# Altium schematic sheet Connector.SchDoc
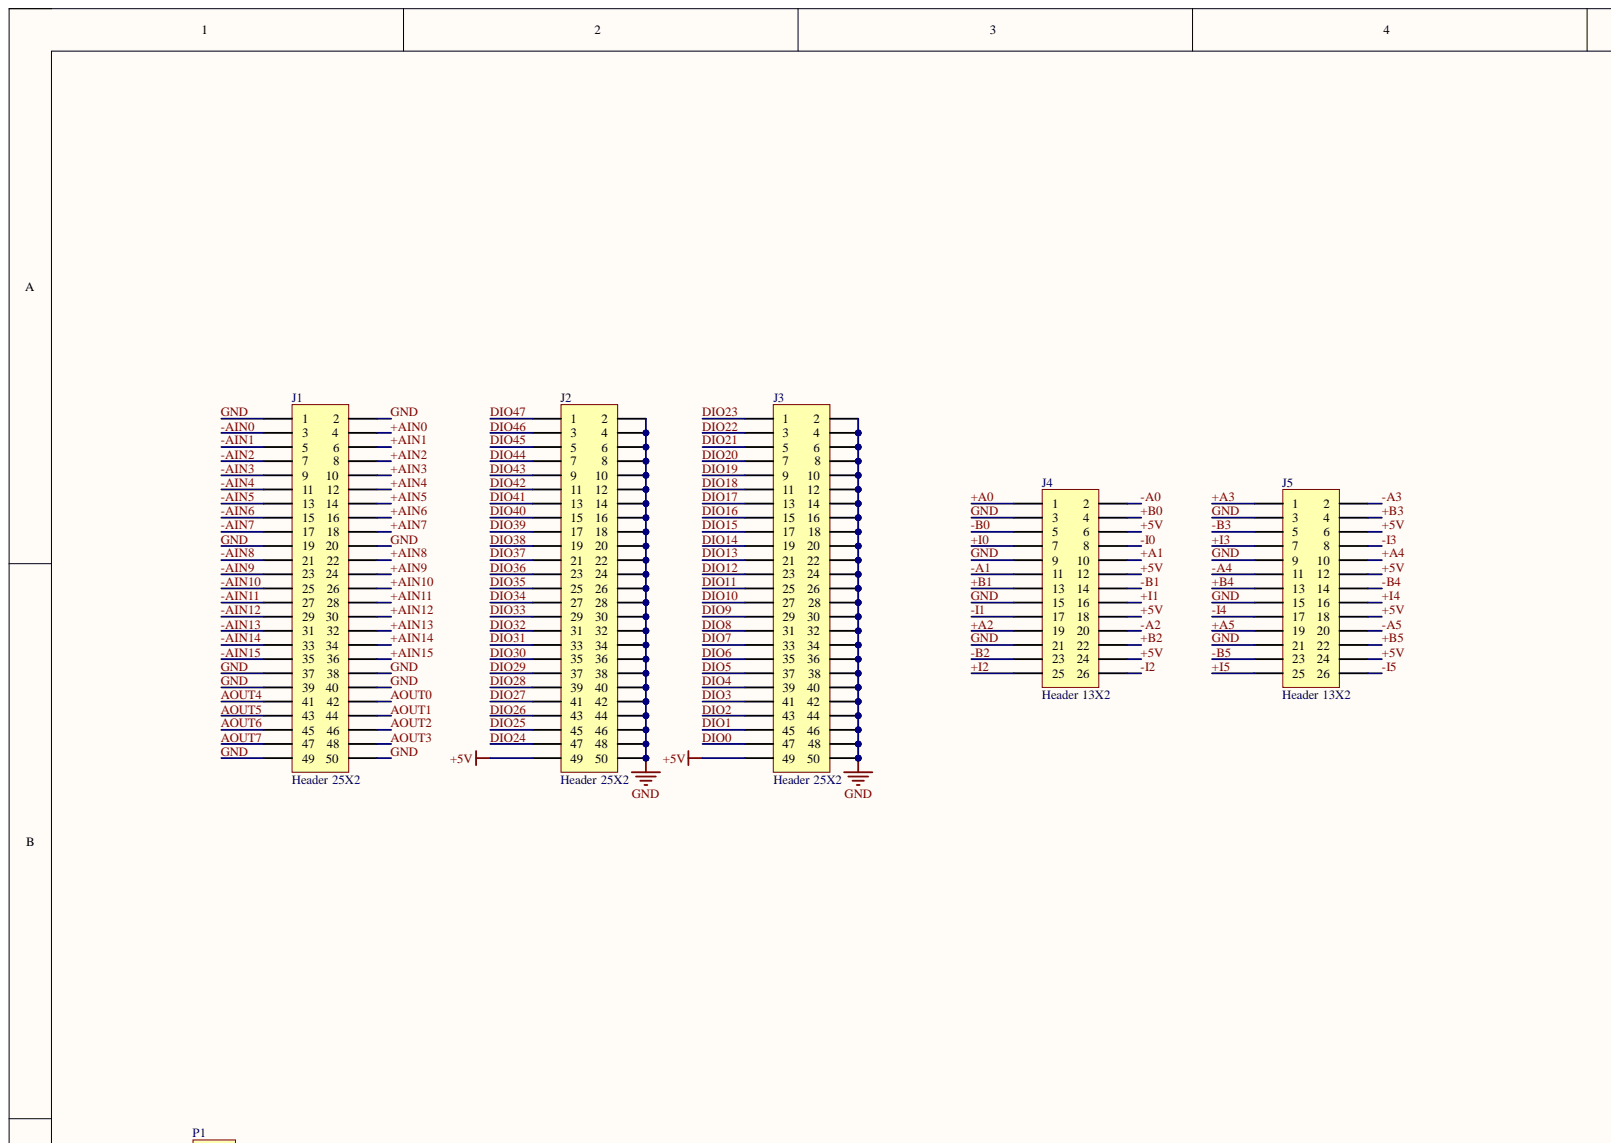
[diagram p0: Connector.SchDoc, top left]
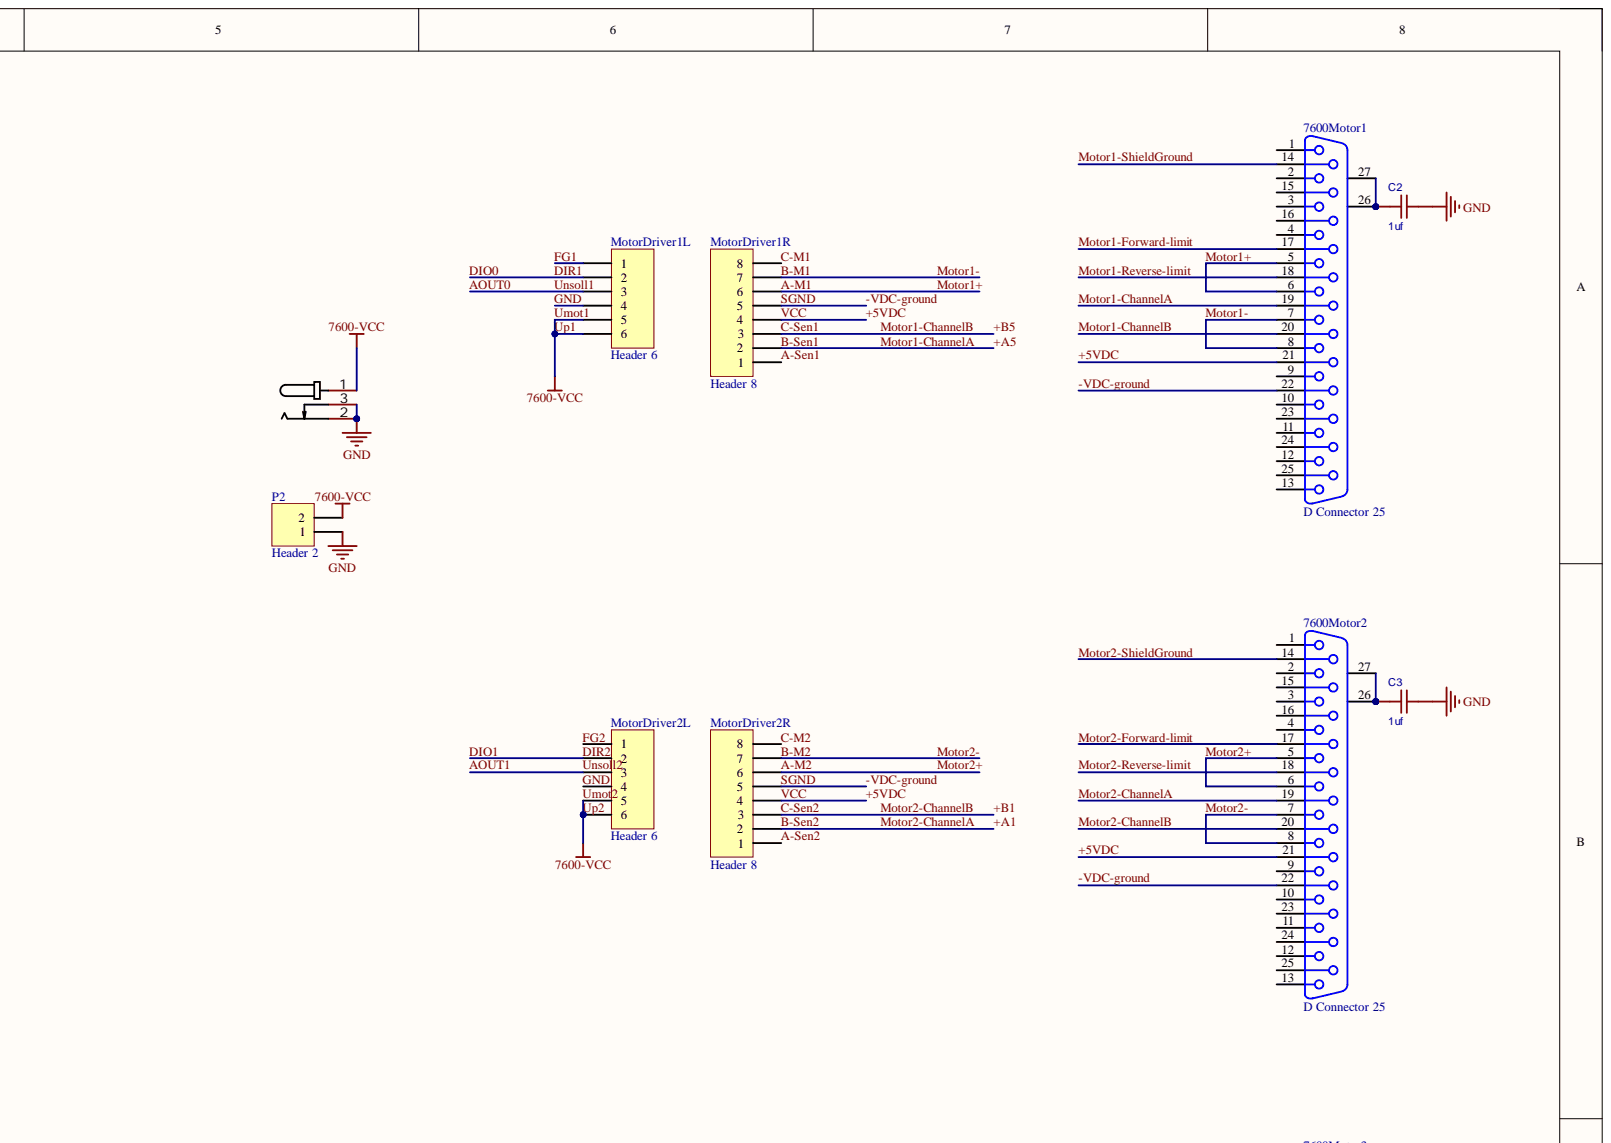
[diagram p1: Connector.SchDoc, top right]
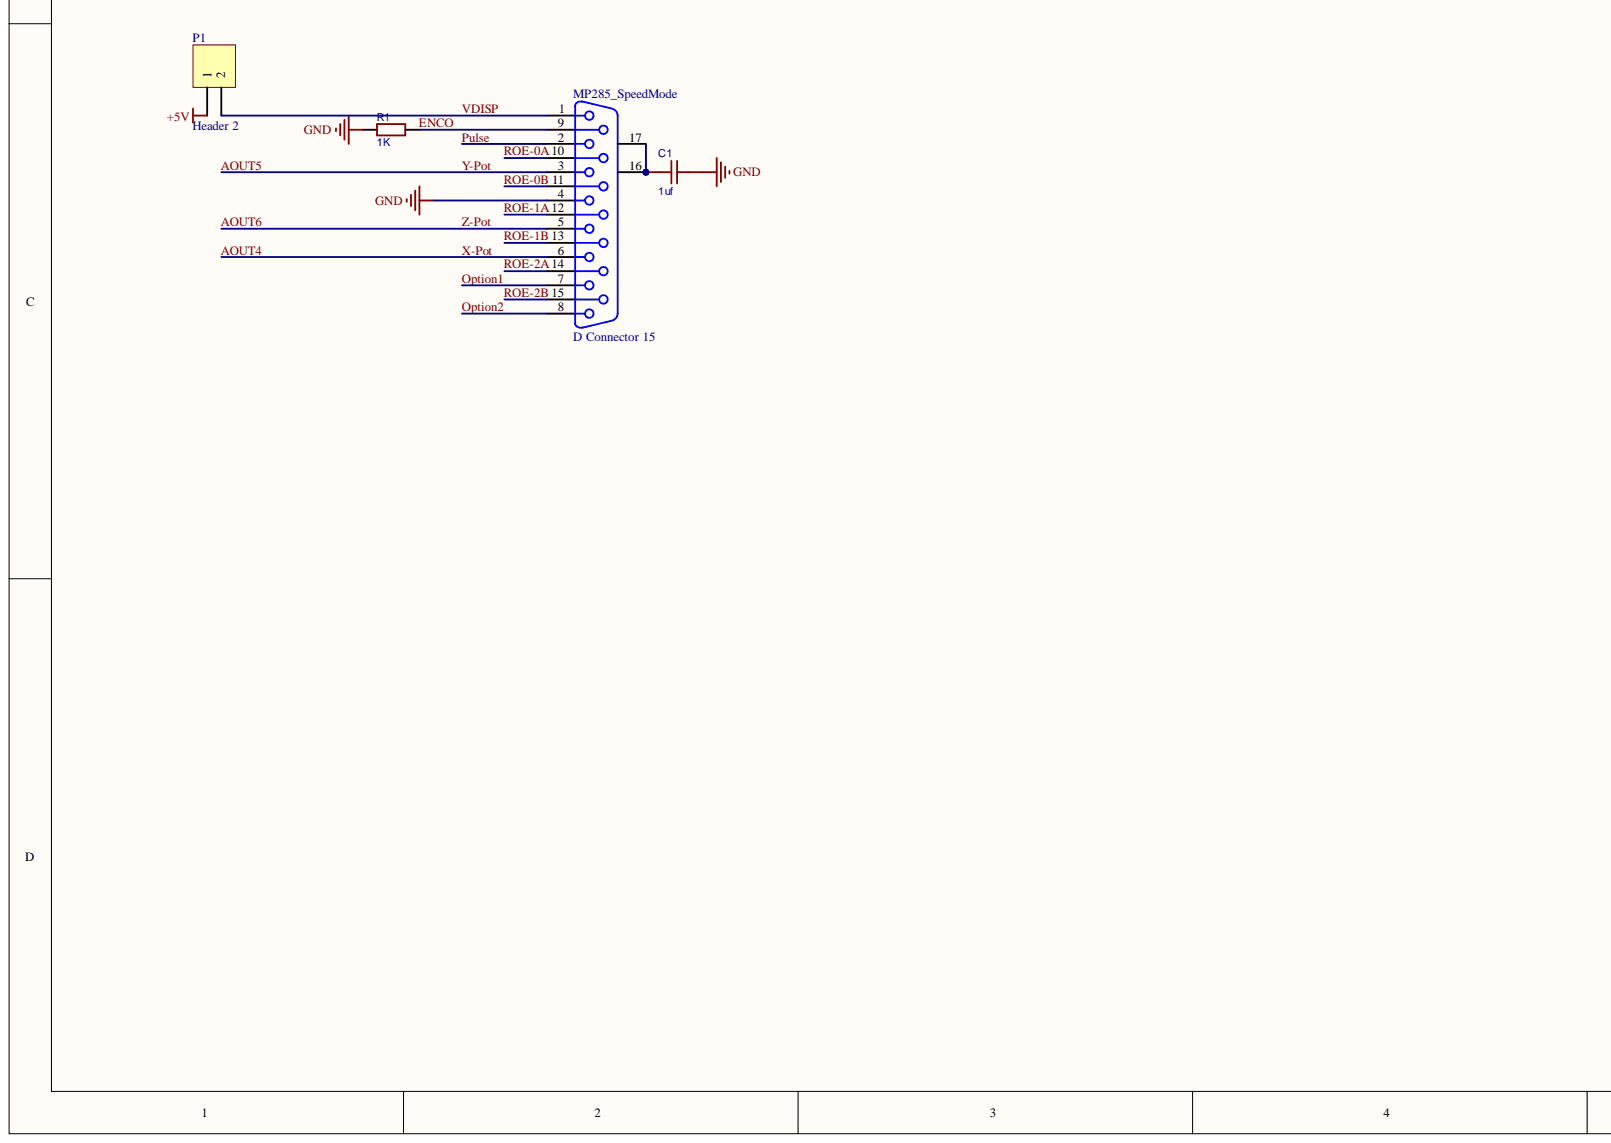
[diagram p2: Connector.SchDoc, bottom left]
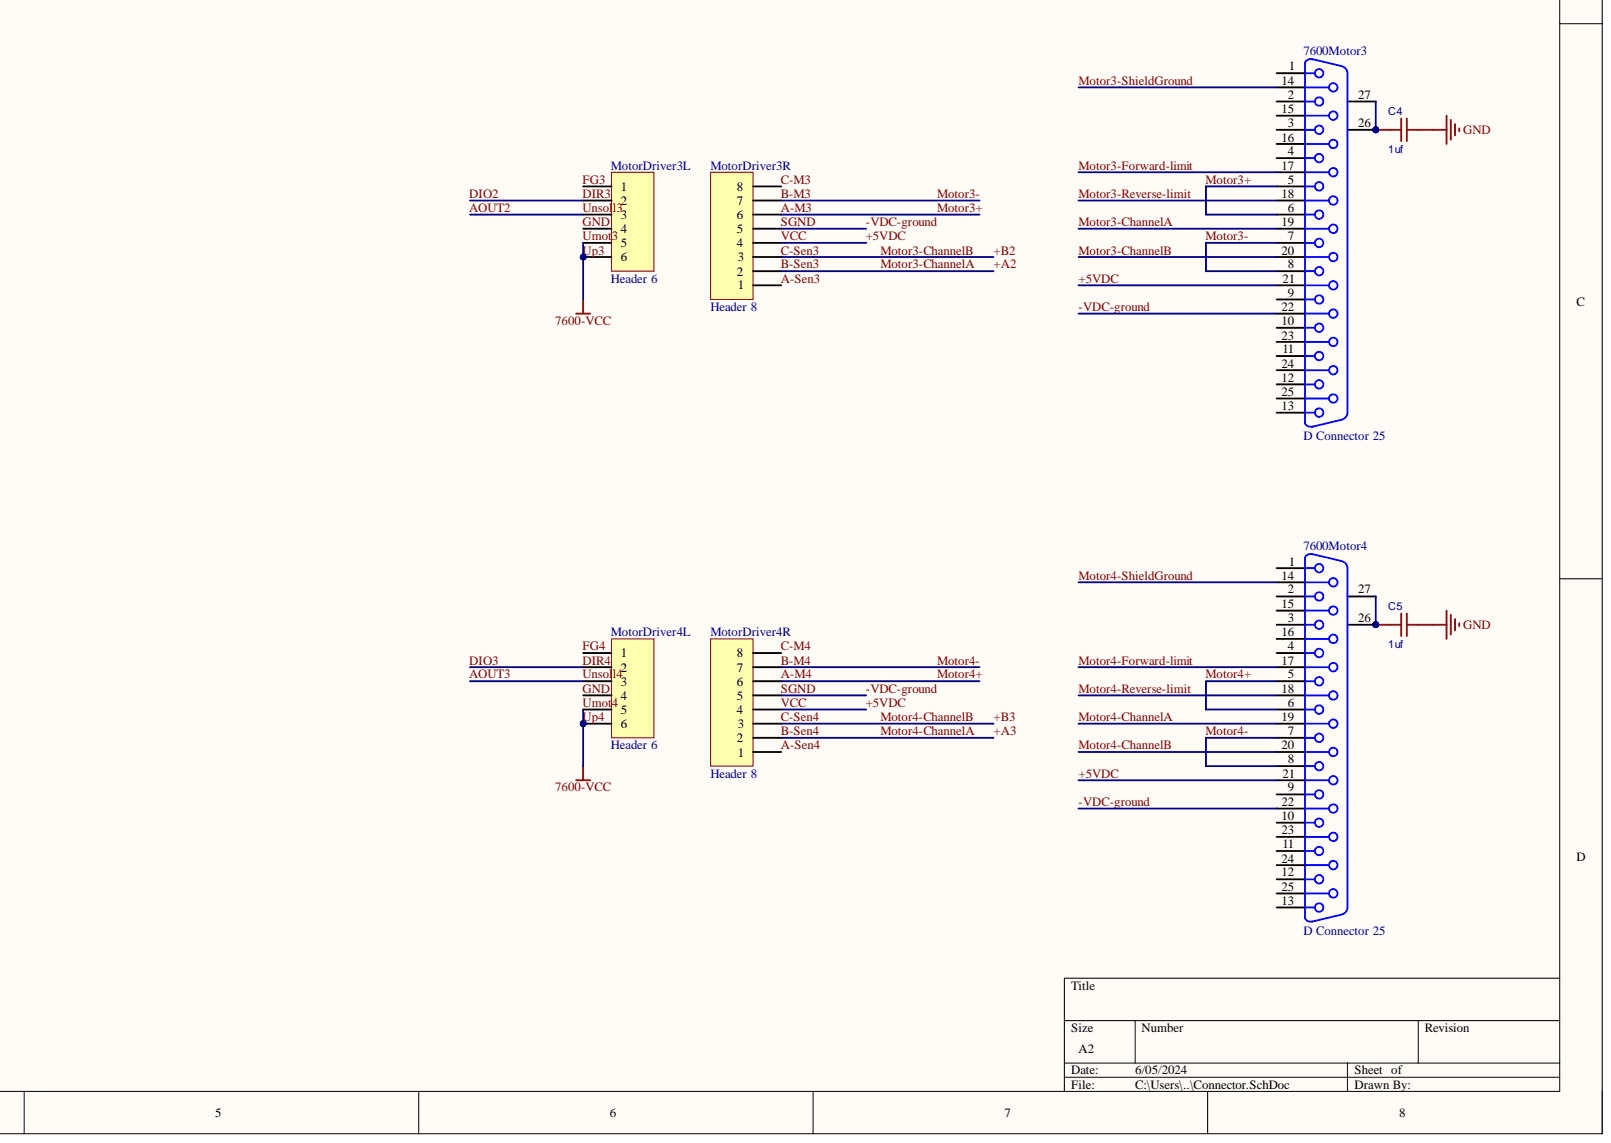
[diagram p3: Connector.SchDoc, bottom right]

## Parts
7600Motor1  D Connector 25  [DSUB1.385-2H25A]
7600Motor2  D Connector 25  [DSUB1.385-2H25A]
7600Motor3  D Connector 25  [DSUB1.385-2H25A]
7600Motor4  D Connector 25  [DSUB1.385-2H25A]
C1  1uf  [0603C]
C2  1uf  [0603C]
C3  1uf  [0603C]
C4  1uf  [0603C]
C5  1uf  [0603C]
DC1  DC-005-A200  [DC-IN-TH_DC-005-A200]  (value not drawn)
J1  Header 25X2  [HDR2X25]
J2  Header 25X2  [HDR2X25]
J3  Header 25X2  [HDR2X25]
J4  Header 13X2  [HDR2X13]
J5  Header 13X2  [HDR2X13]
MotorDriver1L  Header 6  [HDR1X6]
MotorDriver1R  Header 8  [HDR1X8]
MotorDriver2L  Header 6  [HDR1X6]
MotorDriver2R  Header 8  [HDR1X8]
MotorDriver3L  Header 6  [HDR1X6]
MotorDriver3R  Header 8  [HDR1X8]
MotorDriver4L  Header 6  [HDR1X6]
MotorDriver4R  Header 8  [HDR1X8]
MP285_SpeedMode  D Connector 15  [DSUB1.385-2H15]
P1  Header 2  [HDR1X2]
P2  Header 2  [HDR1X2]
R1  1K  [0603R]

## Nets
+5V: J2.49 J3.49 J4.6 J4.12 J4.18 J4.24 J5.6 J5.12 J5.18 J5.24 P1.1
+5VDC: 7600Motor1.21 7600Motor2.21 7600Motor3.21 7600Motor4.21 MotorDriver1R.4 MotorDriver2R.4 MotorDriver3R.4 MotorDriver4R.4
+A0: J4.1
+A1: 7600Motor2.19 J4.10 MotorDriver2R.2
+A2: 7600Motor3.19 J4.19 MotorDriver3R.2
+A3: 7600Motor4.19 J5.1 MotorDriver4R.2
+A4: J5.10
+A5: 7600Motor1.19 J5.19 MotorDriver1R.2
+AIN0: J1.4
+AIN1: J1.6
+AIN2: J1.8
+AIN3: J1.10
+AIN4: J1.12
+AIN5: J1.14
+AIN6: J1.16
+AIN7: J1.18
+AIN8: J1.22
+AIN9: J1.24
+AIN10: J1.26
+AIN11: J1.28
+AIN12: J1.30
+AIN13: J1.32
+AIN14: J1.34
+AIN15: J1.36
+B0: J4.4
+B1: 7600Motor2.20 J4.13 MotorDriver2R.3
+B2: 7600Motor3.20 J4.22 MotorDriver3R.3
+B3: 7600Motor4.20 J5.4 MotorDriver4R.3
+B4: J5.13
+B5: 7600Motor1.20 J5.22 MotorDriver1R.3
+I0: J4.7
+I1: J4.16
+I2: J4.25
+I3: J5.7
+I4: J5.16
+I5: J5.25
-A0: J4.2
-A1: J4.11
-A2: J4.20
-A3: J5.2
-A4: J5.11
-A5: J5.20
-AIN0: J1.3
-AIN1: J1.5
-AIN2: J1.7
-AIN3: J1.9
-AIN4: J1.11
-AIN5: J1.13
-AIN6: J1.15
-AIN7: J1.17
-AIN8: J1.21
-AIN9: J1.23
-AIN10: J1.25
-AIN11: J1.27
-AIN12: J1.29
-AIN13: J1.31
-AIN14: J1.33
-AIN15: J1.35
-B0: J4.5
-B1: J4.14
-B2: J4.23
-B3: J5.5
-B4: J5.14
-B5: J5.23
-I0: J4.8
-I1: J4.17
-I2: J4.26
-I3: J5.8
-I4: J5.17
-I5: J5.26
-VDC-ground: 7600Motor1.22 7600Motor2.22 7600Motor3.22 7600Motor4.22 MotorDriver1R.5 MotorDriver2R.5 MotorDriver3R.5 MotorDriver4R.5
A-M1: 7600Motor1.5 7600Motor1.6 MotorDriver1R.6
A-M2: 7600Motor2.5 7600Motor2.6 MotorDriver2R.6
A-M3: 7600Motor3.5 7600Motor3.6 MotorDriver3R.6
A-M4: 7600Motor4.5 7600Motor4.6 MotorDriver4R.6
A-Sen1: MotorDriver1R.1
A-Sen2: MotorDriver2R.1
A-Sen3: MotorDriver3R.1
A-Sen4: MotorDriver4R.1
AOUT0: J1.42 MotorDriver1L.3
AOUT1: J1.44 MotorDriver2L.3
AOUT2: J1.46 MotorDriver3L.3
AOUT3: J1.48 MotorDriver4L.3
AOUT4: J1.41 MP285_SpeedMode.6
AOUT5: J1.43 MP285_SpeedMode.3
AOUT6: J1.45 MP285_SpeedMode.5
AOUT7: J1.47
B-M1: 7600Motor1.7 7600Motor1.8 MotorDriver1R.7
B-M2: 7600Motor2.7 7600Motor2.8 MotorDriver2R.7
B-M3: 7600Motor3.7 7600Motor3.8 MotorDriver3R.7
B-M4: 7600Motor4.7 7600Motor4.8 MotorDriver4R.7
C-M1: MotorDriver1R.8
C-M2: MotorDriver2R.8
C-M3: MotorDriver3R.8
C-M4: MotorDriver4R.8
DIO0: J3.47 MotorDriver1L.2
DIO1: J3.45 MotorDriver2L.2
DIO2: J3.43 MotorDriver3L.2
DIO3: J3.41 MotorDriver4L.2
DIO4: J3.39
DIO5: J3.37
DIO6: J3.35
DIO7: J3.33
DIO8: J3.31
DIO9: J3.29
DIO10: J3.27
DIO11: J3.25
DIO12: J3.23
DIO13: J3.21
DIO14: J3.19
DIO15: J3.17
DIO16: J3.15
DIO17: J3.13
DIO18: J3.11
DIO19: J3.9
DIO20: J3.7
DIO21: J3.5
DIO22: J3.3
DIO23: J3.1
DIO24: J2.47
DIO25: J2.45
DIO26: J2.43
DIO27: J2.41
DIO28: J2.39
DIO29: J2.37
DIO30: J2.35
DIO31: J2.33
DIO32: J2.31
DIO33: J2.29
DIO34: J2.27
DIO35: J2.25
DIO36: J2.23
DIO37: J2.21
DIO38: J2.19
DIO39: J2.17
DIO40: J2.15
DIO41: J2.13
DIO42: J2.11
DIO43: J2.9
DIO44: J2.7
DIO45: J2.5
DIO46: J2.3
DIO47: J2.1
ENCO: MP285_SpeedMode.9 R1.2
FG1: MotorDriver1L.1
FG2: MotorDriver2L.1
FG3: MotorDriver3L.1
FG4: MotorDriver4L.1
GND: C1.2 C2.2 C3.2 C4.2 C5.2 DC1.2 DC1.3 J1.1 J1.2 J1.19 J1.20 J1.37 J1.38 J1.39 J1.40 J1.49 J1.50 J2.2 J2.4 J2.6 J2.8 J2.10 J2.12 J2.14 J2.16 J2.18 J2.20 J2.22 J2.24 J2.26 J2.28 J2.30 J2.32 J2.34 J2.36 J2.38 J2.40 J2.42 J2.44 J2.46 J2.48 J2.50 J3.2 J3.4 J3.6 J3.8 J3.10 J3.12 J3.14 J3.16 J3.18 J3.20 J3.22 J3.24 J3.26 J3.28 J3.30 J3.32 J3.34 J3.36 J3.38 J3.40 J3.42 J3.44 J3.46 J3.48 J3.50 J4.3 J4.9 J4.15 J4.21 J5.3 J5.9 J5.15 J5.21 MotorDriver1L.4 MotorDriver2L.4 MotorDriver3L.4 MotorDriver4L.4 MP285_SpeedMode.4 P2.1 R1.1
Motor1-Forward-limit: 7600Motor1.17
Motor1-Reverse-limit: 7600Motor1.18
Motor1-ShieldGround: 7600Motor1.14
Motor2-Forward-limit: 7600Motor2.17
Motor2-Reverse-limit: 7600Motor2.18
Motor2-ShieldGround: 7600Motor2.14
Motor3-Forward-limit: 7600Motor3.17
Motor3-Reverse-limit: 7600Motor3.18
Motor3-ShieldGround: 7600Motor3.14
Motor4-Forward-limit: 7600Motor4.17
Motor4-Reverse-limit: 7600Motor4.18
Motor4-ShieldGround: 7600Motor4.14
Option1: MP285_SpeedMode.7
Option2: MP285_SpeedMode.8
Pulse: MP285_SpeedMode.2
ROE-0A: MP285_SpeedMode.10
ROE-0B: MP285_SpeedMode.11
ROE-1A: MP285_SpeedMode.12
ROE-1B: MP285_SpeedMode.13
ROE-2A: MP285_SpeedMode.14
ROE-2B: MP285_SpeedMode.15
Umot1: DC1.1 MotorDriver1L.5 MotorDriver1L.6 MotorDriver2L.5 MotorDriver2L.6 MotorDriver3L.5 MotorDriver3L.6 MotorDriver4L.5 MotorDriver4L.6 P2.2
VDISP: MP285_SpeedMode.1 P1.2
Net7600Motor1_26: 7600Motor1.26 7600Motor1.27 C2.1
Net7600Motor2_26: 7600Motor2.26 7600Motor2.27 C3.1
Net7600Motor3_26: 7600Motor3.26 7600Motor3.27 C4.1
Net7600Motor4_26: 7600Motor4.26 7600Motor4.27 C5.1
NetC1_1: C1.1 MP285_SpeedMode.16 MP285_SpeedMode.17

Not connected: 7600Motor1 1 2 3 4 9 10 11 12 13 15 16 23 24 25; 7600Motor2 1 2 3 4 9 10 11 12 13 15 16 23 24 25; 7600Motor3 1 2 3 4 9 10 11 12 13 15 16 23 24 25; 7600Motor4 1 2 3 4 9 10 11 12 13 15 16 23 24 25

## Library data of the parts
7600Motor1-7600Motor4 = D Connector 25: Receptacle Assembly, 25 Position, Right Angle; PackageDocument: U; Positions: 25; Component Kind: Standard; DatasheetDocument: 19-Mar-1999; Gender: Receptacle; LatestRevisionDate: 17-Jul-2002; LatestRevisionNote: Re-released for DXP Platform.; Library Name: Miscellaneous Connectors.IntLib; Orientation: Right Angle; PackageReference: 787202; Published: 15-Jun-2001; Signal Integrity: Connector; Publisher: Altium Limited
C1-C5 = 1uf: BOM_Manufacturer: Murata Electronics; BOM_Manufacturer Part: GRM0335C1H150FA01D; Contributor: LCSC; nameAlias: Capacitance; BOM_JLCPCB Part Class: Extended Part; BOM_Supplier: LCSC; BOM_Supplier Part: C237567; Component Kind: Standard; spicePre: C; spiceSymbolName: GRM0335C1H150FA01D; Library Name: QuadImpeBoard.IntLib
DC1 = DC-005-A200: Manufacturer: XUNPU(讯普); Add into BOM: yes; Connector Type: DC电源插座; Current Rating (Max): 3A; Inside Contact Diameter: 2mm; Outside Contact Diameter: 6.4mm; Voltage Rating (Max): 30V; Component Kind: Standard; Convert to PCB: yes; Datasheet: https://atta.szlcsc.com/upload/public/pdf/source/20200812/C720557_2286DFCD6C75B71AF905731F627ABF3A.pdf; Device: DC-005-A200; JLCPCB Part Class: Extended Part; LCSC Part Name: DC电源插座 内径:2mm 外径:6.4mm; Manufacturer Part: DC-005-A200; Mounting Style: 弯插; Operating Temperature Range: -20℃~+70℃; Supplier: LCSC; Supplier Footprint: 插件; Supplier Part: C720557; Symbol: DC-005-A200; Library Name: InjectionLibs.IntLib
J1-J3 = Header 25X2: Header, 25-Pin, Dual row; Component Kind: Standard; LatestRevisionDate: 17-Jul-2002; LatestRevisionNote: Re-released for DXP Platform.; Library Name: Miscellaneous Connectors.IntLib; Signal Integrity: Connector; Publisher: Altium Limited
J4 J5 = Header 13X2: Header, 13-Pin, Dual row; Component Kind: Standard; LatestRevisionDate: 17-Jul-2002; LatestRevisionNote: Re-released for DXP Platform.; Library Name: Miscellaneous Connectors.IntLib; Signal Integrity: Connector; Publisher: Altium Limited
MotorDriver1L MotorDriver2L MotorDriver3L MotorDriver4L = Header 6: Header, 6-Pin; Component Kind: Standard; LatestRevisionDate: 17-Jul-2002; LatestRevisionNote: Re-released for DXP Platform.; Library Name: Miscellaneous Connectors.IntLib; Signal Integrity: Connector; Publisher: Altium Limited
MotorDriver1R MotorDriver2R MotorDriver3R MotorDriver4R = Header 8: Header, 8-Pin; Component Kind: Standard; LatestRevisionDate: 17-Jul-2002; LatestRevisionNote: Re-released for DXP Platform.; Library Name: Miscellaneous Connectors.IntLib; Signal Integrity: Connector; Publisher: Altium Limited
MP285_SpeedMode = D Connector 15: Receptacle Assembly, 15 Position, Right Angle; PackageDocument: O; Positions: 15; Component Kind: Standard; DatasheetDocument: 1-Sep-99; Gender: Receptacle; LatestRevisionDate: 17-Jul-2002; LatestRevisionNote: Re-released for DXP Platform.; Library Name: Miscellaneous Connectors.IntLib; Orientation: Right Angle; PackageReference: 788757; Published: 15-Jun-2001; Signal Integrity: Connector; Publisher: Altium Limited
P1 P2 = Header 2: Header, 2-Pin; Component Kind: Standard; LatestRevisionDate: 17-Jul-2002; LatestRevisionNote: Re-released for DXP Platform.; Library Name: Miscellaneous Connectors.IntLib; Signal Integrity: Connector; Publisher: Altium Limited
R1 = 1K: BOM_Manufacturer: UniOhm; BOM_Manufacturer Part: 0603WAF1001T5E; nameAlias: Resistance (Ohms); BOM_JLCPCB Part Class: Basic Part; BOM_Supplier: LCSC; BOM_Supplier Part: C21190; Component Kind: Standard; spicePre: R; spiceSymbolName: 0603WAF1001T5E; Library Name: QuadImpeBoard.IntLib
